# Altium schematic sheet Key-HT16K23.SchDoc(Key-HT16K23)
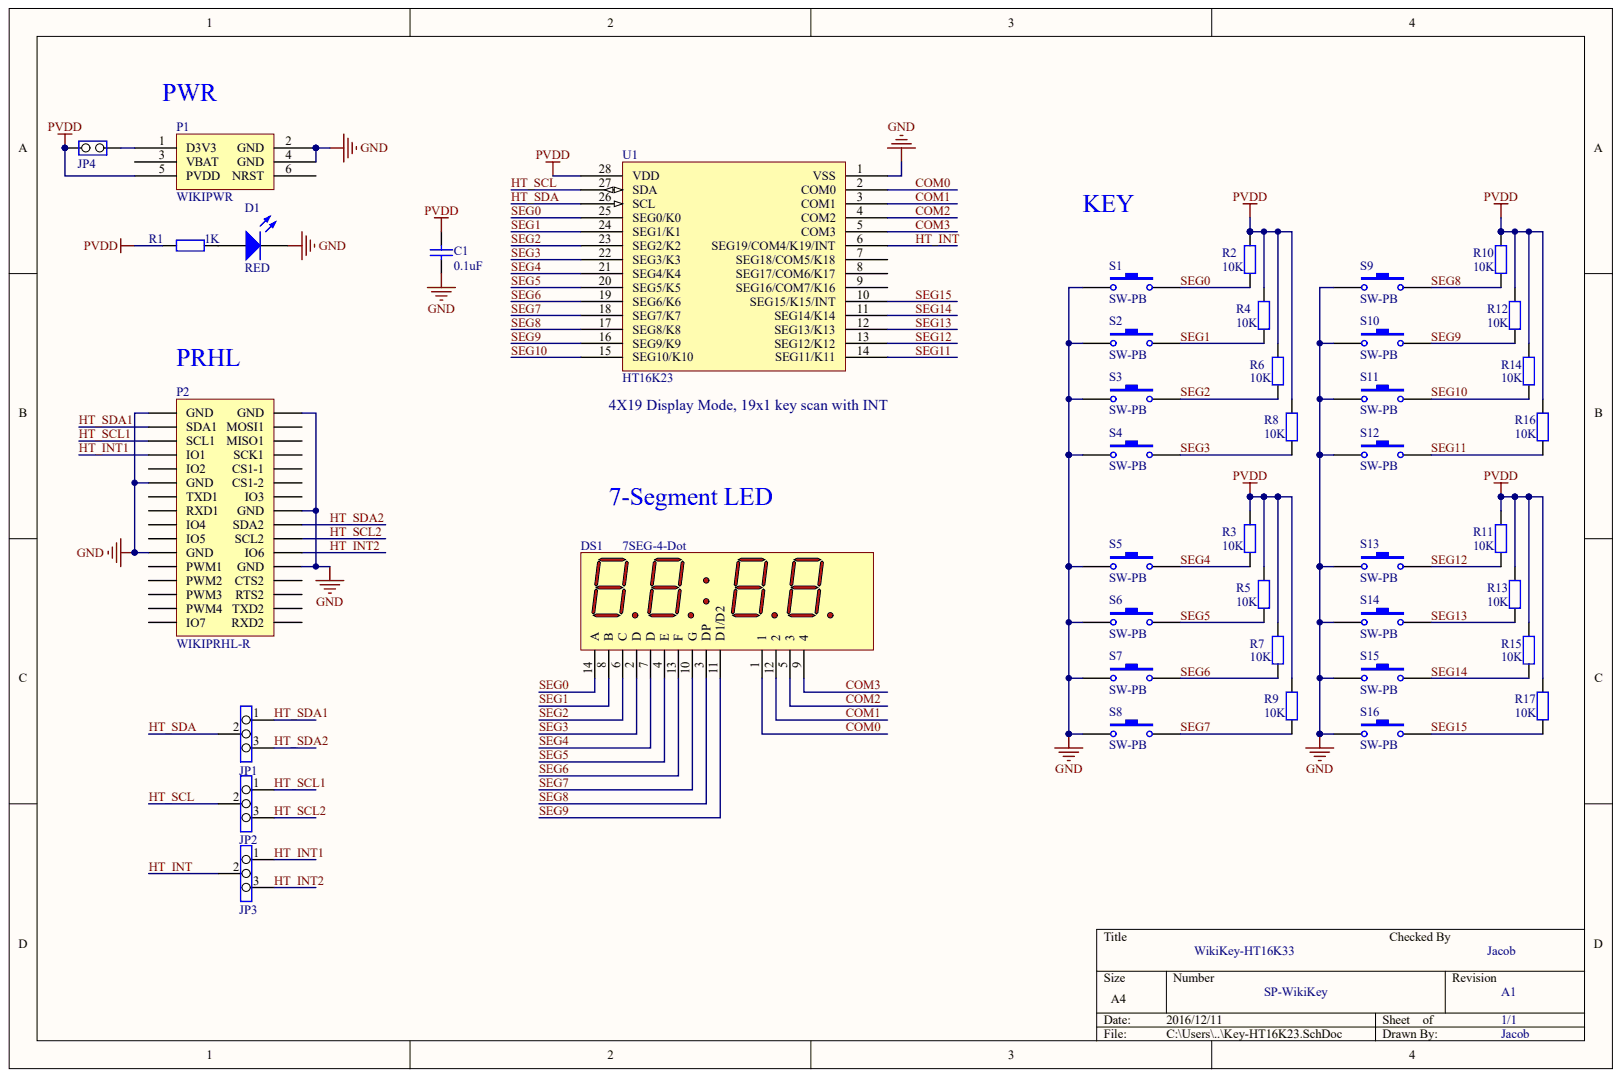
[diagram p0: Key-HT16K23.SchDoc]

## Parts
C1  0.1uF
D1  RED
DS1  7SEG-4-Dot
JP1  Jumper3  (value not drawn)
JP2  Jumper3  (value not drawn)
JP3  Jumper3  (value not drawn)
JP4  Jumper2-2  (value not drawn)
P1  WIKIPWR
P2  WIKIPRHL-R
R1  1K
R2  10K
R3  10K
R4  10K
R5  10K
R6  10K
R7  10K
R8  10K
R9  10K
R10  10K
R11  10K
R12  10K
R13  10K
R14  10K
R15  10K
R16  10K
R17  10K
S1  SW-PB
S2  SW-PB
S3  SW-PB
S4  SW-PB
S5  SW-PB
S6  SW-PB
S7  SW-PB
S8  SW-PB
S9  SW-PB
S10  SW-PB
S11  SW-PB
S12  SW-PB
S13  SW-PB
S14  SW-PB
S15  SW-PB
S16  SW-PB
U1  HT16K23

## Nets
GND: C1.1 D1.2 P1.2(GND) P1.4(GND) P2.1(GND) P2.2(GND) P2.11(GND) P2.16(GND) P2.21(GND) P2.24(GND) S1.1 S2.1 S3.1 S4.1 S5.1 S6.1 S7.1 S8.1 S9.1 S10.1 S11.1 S12.1 S13.1 S14.1 S15.1 S16.1 U1.1(VSS)
PVDD: C1.2 JP4.2 P1.5(PVDD) R1.2 R2.1 R3.1 R4.1 R5.1 R6.1 R7.1 R8.1 R9.1 R10.1 R11.1 R12.1 R13.1 R14.1 R15.1 R16.1 R17.1 U1.28(VDD)
COM0: DS1.1 U1.2(COM0)
COM1: DS1.12 U1.3(COM1)
COM2: DS1.5 U1.4(COM2)
COM3: DS1.9 U1.5(COM3)
HT_INT: JP3.2 U1.6(SEG19/COM4/K19/INT)
HT_INT1: JP3.1 P2.7(IO1)
HT_INT2: JP3.3 P2.22(IO6)
HT_SCL: JP2.2 U1.27(SDA)
HT_SCL1: JP2.1 P2.5(SCL1)
HT_SCL2: JP2.3 P2.20(SCL2)
HT_SDA: JP1.2 U1.26(SCL)
HT_SDA1: JP1.1 P2.3(SDA1)
HT_SDA2: JP1.3 P2.18(SDA2)
SEG0: DS1.14(A) R2.2 S1.2 U1.25(SEG0/K0)
SEG1: DS1.8(B) R4.2 S2.2 U1.24(SEG1/K1)
SEG2: DS1.6(C) R6.2 S3.2 U1.23(SEG2/K2)
SEG3: DS1.2(D) R8.2 S4.2 U1.22(SEG3/K3)
SEG4: DS1.7(D) R3.2 S5.2 U1.21(SEG4/K4)
SEG5: DS1.4(E) R5.2 S6.2 U1.20(SEG5/K5)
SEG6: DS1.13(F) R7.2 S7.2 U1.19(SEG6/K6)
SEG7: DS1.10(G) R9.2 S8.2 U1.18(SEG7/K7)
SEG8: DS1.3(DP) R10.2 S9.2 U1.17(SEG8/K8)
SEG9: DS1.11(D1/D2) R12.2 S10.2 U1.16(SEG9/K9)
SEG10: R14.2 S11.2 U1.15(SEG10/K10)
SEG11: R16.2 S12.2 U1.14(SEG11/K11)
SEG12: R11.2 S13.2 U1.13(SEG12/K12)
SEG13: R13.2 S14.2 U1.12(SEG13/K13)
SEG14: R15.2 S15.2 U1.11(SEG14/K14)
SEG15: R17.2 S16.2 U1.10(SEG15/K15/INT)
NetD1_1: D1.1 R1.1
NetJP4_1: JP4.1 P1.1(D3V3)

Not connected: P1 3(VBAT) 6(NRST); P2 4(MOSI1) 6(MISO1) 8(SCK1) 9(IO2) 10(CS1-1) 12(CS1-2) 13(TXD1) 14(IO3) 15(RXD1) 17(IO4) 19(IO5) 23(PWM1) 25(PWM2) 26(CTS2) 27(PWM3) 28(RTS2) 29(PWM4) 30(TXD2) 31(IO7) 32(RXD2); U1 7(SEG18/COM5/K18) 8(SEG17/COM6/K17) 9(SEG16/COM7/K16)

## Library data of the parts
DS1 = (no symbol name): MinimumIntensity: 360 ucd; Note: IF = 5 mA; Colour: High Efficiency Red (HER); Note: Pins 1 and 6 are redundant Cathodes.; Published: 8-Jun-2000; LatestRevisionDate: 17-Dec-2003; LatestRevisionNote: Schematic revised, PCB Footprint replaced.; DatasheetDocument: 19-Feb-2002; PackageDocument: 19-Feb-2002; PackageReference: LEDDIP-10; ComponentLink1Description: Manufacturer Link; ComponentLink1URL: http://www.agilent.com/; Publisher: Altium Limited
C1 D1 JP1-JP4 P1 P2 R1-R17 S1-S16 U1 = (no symbol name)
  C1: Published: 8-Jun-2000; LatestRevisionDate: 17-Jul-2002; LatestRevisionNote: Re-released for DXP Platform.; PackageReference: RAD-0.3; Value: 0.1uF
  D1: Published: 8-Jun-2000; LatestRevisionDate: 08-Jul-2005; LatestRevisionNote: Mech Layer 1 removed.; PackageReference: LED-0
  P2: LatestRevisionDate: 17-Jul-2002; LatestRevisionNote: Re-released for DXP Platform.
  R1: Published: 8-Jun-2000; LatestRevisionDate: 17-Jul-2002; LatestRevisionNote: Re-released for DXP Platform.; PackageReference: AXIAL-0.4; Value: 1K
  R2-R17: Published: 8-Jun-2000; LatestRevisionDate: 17-Jul-2002; LatestRevisionNote: Re-released for DXP Platform.; PackageReference: AXIAL-0.4; Value: 10K
  S1-S16: Published: 24-Mar-1999; LatestRevisionDate: 17-Jul-2002; LatestRevisionNote: Re-released for DXP Platform.; PackageReference: SPST-2
  C1 D1 P2 R1-R17 S1-S16: Publisher: Altium Limited
